# Altium schematic sheet isoSPI_Interface.SchDoc(isoSPI_Interface)
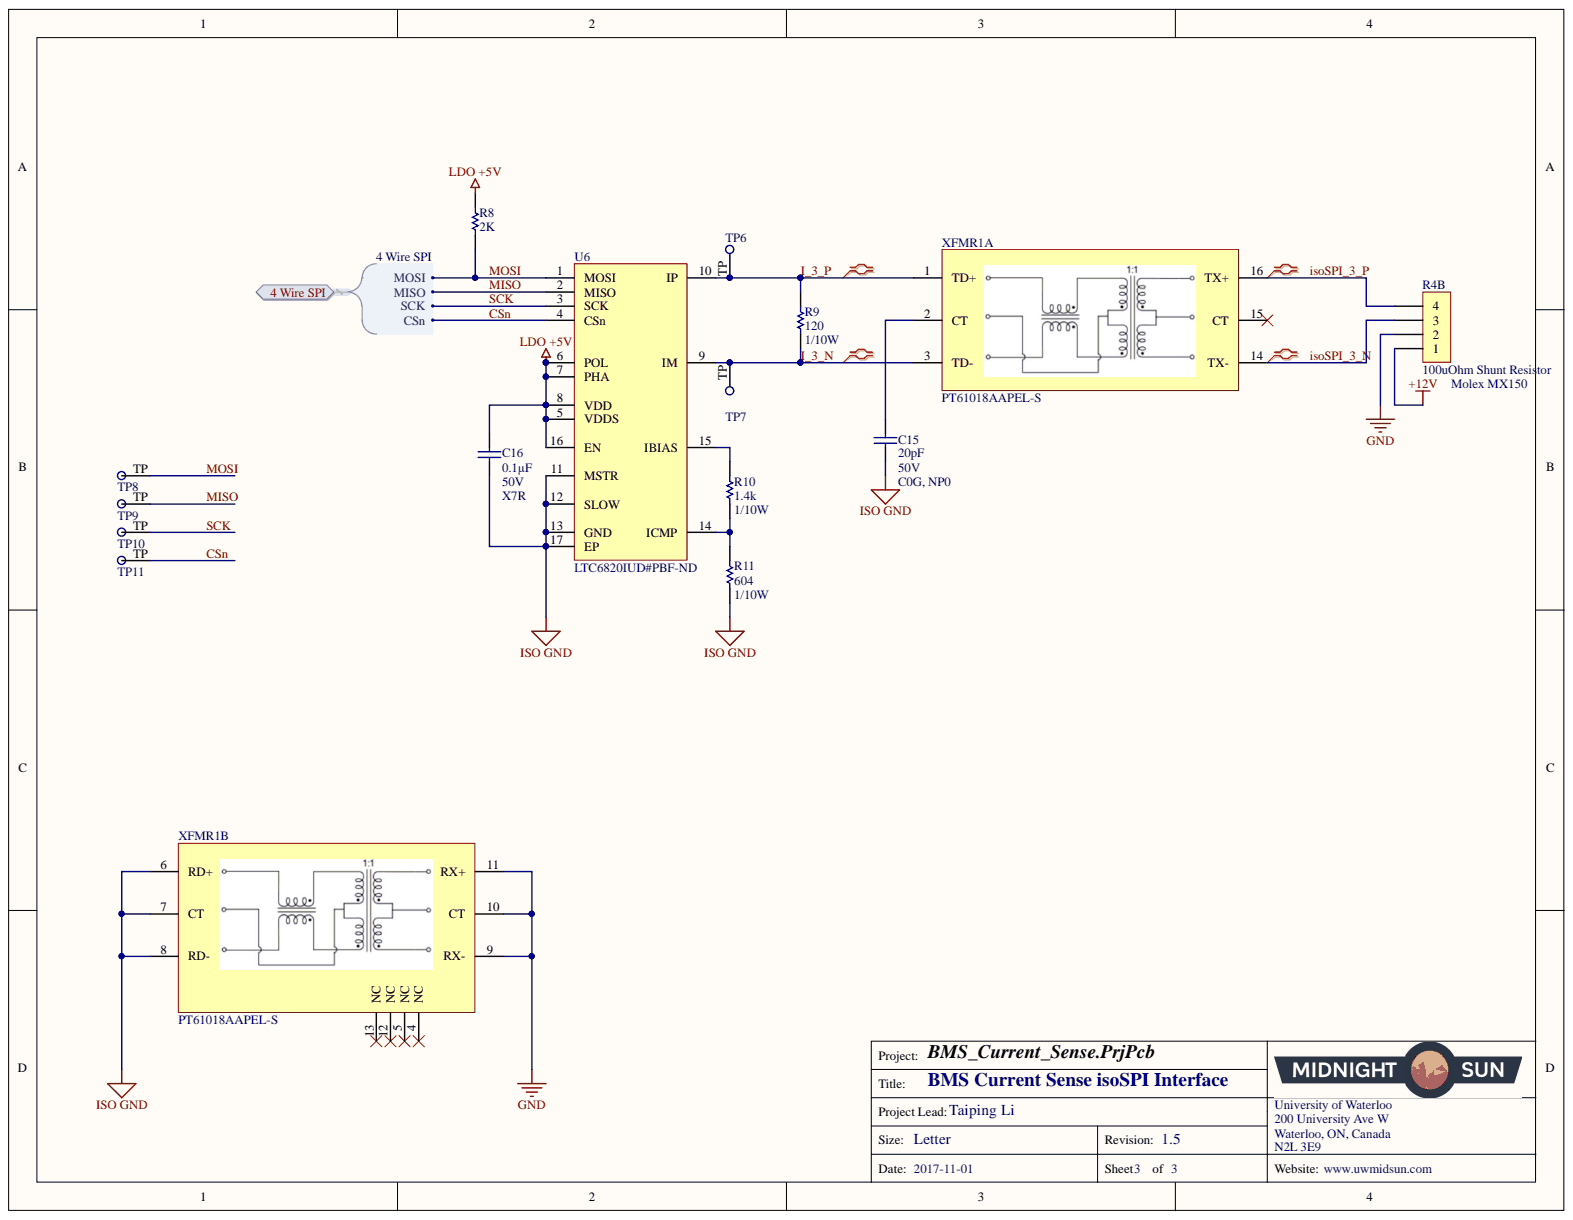
[diagram p0: isoSPI_Interface.SchDoc]

## Parts
C15  Voltage: 50V  Capacitance: 20pF  [CAP, 0603]  (hidden comment: CAP CER 20PF 50V ±5% C0G/NP0 0603)
C16  Voltage: 50V  Temperature Coefficient: X7R  Capacitance: 0.1µF  [CAP, 0603]  (hidden comment: CAP CER 0.1UF 50V 10% X7R 0603)
R4  100uOhm Shunt Resistor  [RES SHUNT 100UOHM 60MM]  (part B)
R8  2K  [RES, 0603]
R9  Power: 1/10W  [RES, 0603]  (hidden comment: RES 120 OHM 1% 1/10W 0603)
R10  Resistance: 1.4k  Power: 1/10W  [RES, 0603]  (hidden comment: RES 1.4k OHM 1% 1/10W 0603)
R11  Power: 1/10W  [RES, 0603]  (hidden comment: RES 604 OHM 1% 1/10W 0603)
TP6  Test Point  [Test Point]  (value not drawn)
TP7  Test Point  [Test Point]  (value not drawn)
TP8  Test Point  [Test Point]  (value not drawn)
TP9  Test Point  [Test Point]  (value not drawn)
TP10  Test Point  [Test Point]  (value not drawn)
TP11  Test Point  [Test Point]  (value not drawn)
U6  Supplier Part Number 1: LTC6820IUD#PBF-ND  [IC COMM INTERFACE ISOSPI 16-QFN]  (hidden comment: IC ISOSPI COMM INTERFACE LTC6820IUD)
XFMR1  MFG_PN: PT61018AAPEL-S  [IC, PULSE XFMR 1CT:1CT 350UH SMD]  (hidden comment: IC PULSE XFMR 1CT:1CT 350UH SMD)  (parts A,B)

## Ports
4 Wire SPI, 4 Wire SPI.CSn, 4 Wire SPI.MISO, 4 Wire SPI.MOSI, 4 Wire SPI.SCK

## Nets
+12V: R4.1
GND: R4.2 XFMR1.9(RX-) XFMR1.10(CT) XFMR1.11(RX+)
ISO GND: C15.2 C16.2 R11.2 U6.11(MSTR) U6.12(SLOW) U6.13(GND) U6.17(EP) XFMR1.6(RD+) XFMR1.7(CT) XFMR1.8(RD-)
LDO +5V: C16.1 R8.1 U6.5(VDDS) U6.6(POL) U6.7(PHA) U6.8(VDD) U6.16(EN)
CSn: TP11.TP U6.4(CSn) ; port 4 Wire SPI
I_3_N: R9.2 TP7.TP U6.9(IM) XFMR1.3(TD-)  [also labelled I_N]
I_3_P: R9.1 TP6.TP U6.10(IP) XFMR1.1(TD+)  [also labelled I_P]
isoSPI_3_N: R4.3 XFMR1.14(TX-)  [also labelled isoSPI_N]
isoSPI_3_P: R4.4 XFMR1.16(TX+)  [also labelled isoSPI_P]
MISO: TP9.TP U6.2(MISO) ; port 4 Wire SPI
MOSI: R8.2 TP8.TP U6.1(MOSI) ; port 4 Wire SPI
SCK: TP10.TP U6.3(SCK) ; port 4 Wire SPI
NetC15_1: C15.1 XFMR1.2(CT)
NetR10_1: R10.1 U6.15(IBIAS)
NetR10_2: R10.2 R11.1 U6.14(ICMP)

Not connected: XFMR1 4(NC) 5(NC) 12(NC) 13(NC) 15(CT)

## Library data of the parts
C15 = CAP CER 20PF 50V ±5% C0G/NP0 0603: 20pF ±5% 50V Ceramic Capacitor C0G, NP0 0603 (1608 Metric); Manufacturer Part Number 1: GRM1885C1H200JA01D; Manufacturer 1: Murata Electronics North America; Tolerance: ±5%; Thickness (Max): 0.035" (0.90mm); Temperature Coefficient: C0G, NP0; Supplier Part Number 1: 490-1410-1-ND; Supplier 1: Digi-Key; Size / Dimension: 0.063" L x 0.031" W (1.60mm x 0.80mm); Ratings: -; Part Status: Active; Packaging: Cut Tape (CT); Package / Case: 0603 (1608 Metric); Operating Temperature: -55°C ~ 125°C; Mounting Type: Surface Mount, MLCC; Lead Style: -; Lead Spacing: -; Height - Seated (Max): -; ComponentLink1URL: http://www.murata.com/~/media/webrenewal/support/library/catalog/products/capacitor/mlcc/c02e.ashx; ComponentLink1Description: http://www.murata.com/~/media/webrenewal/support/library/catalog/products/capacitor/mlcc/c02e.ashx; Component Kind: Standard; Library Name: Schematic Diagrams.SchLib
C16 = CAP CER 0.1UF 50V 10% X7R 0603: 0.10µF ±10% 50V Ceramic Capacitor X7R 0603 (1608 Metric); Manufacturer Part Number 1: 06035C104KAT2A; Manufacturer 1: AVX Corporation; Tolerance: ±10%; Thickness (Max): 0.035" (0.90mm); Supplier Part Number 1: 478-5052-1-ND; Supplier 1: Digi-Key; Size / Dimension: 0.063" L x 0.032" W (1.60mm x 0.81mm); Ratings: -; Part Status: Active; Packaging: Cut Tape (CT); Package / Case: 0603 (1608 Metric); Operating Temperature: -55°C ~ 125°C; Mounting Type: Surface Mount, MLCC; Lead Style: -; Lead Spacing: -; Height - Seated (Max): -; ComponentLink1URL: http://datasheets.avx.com/X7RDielectric.pdf; ComponentLink1Description: http://datasheets.avx.com/X7RDielectric.pdf; Component Kind: Standard; Library Name: Schematic Diagrams.SchLib
R4 = RES SHUNT 100UOHM 5% 36W 60MM: 100 µOhms ±5% 36W Shunt, Battery Specialized Resistor Metal Element ±175ppm/°C Shunt Fixture, 60mm Pitch; Manufacturer Part Number 1: WSBM8518L1000JK; Manufacturer 1: Vishay Dale; Type: Shunt, Battery; Tolerance: ±5%; Temperature Coefficient: ±175ppm/°C; Supplier Part Number 1: 541-1906-ND; Supplier 1: Digi-Key; Stock 1: 0; RoHS: RoHS Compliant; Pricing 1: 1=16.24, 10=14.764, 25=13.2876, 50=11.442, 100=10.5192, 250=9.9656, 500=9.5965, 1000=9.15359 (CAD); Power (Watts): 36W; Part Status: Active; Packaging: Bulk; Operating Temperature: -65°C ~ 170°C; Mounting Type: Shunt Fixture, 60mm Pitch; Description 1: RES SHUNT 100 UOHM 5% 36W; Composition: Metal Element; ComponentLink1URL: http://www.vishay.com/docs/31094/wsbm8518.pdf; ComponentLink1Description: http://www.vishay.com/docs/31094/wsbm8518.pdf; Component Kind: Standard; Category: Resistors; Applications: -; Library Name: Schematic Diagrams.SchLib
R8 = RES 2K OHM 1% 1/10W 0603: 2k Ohm ±1% 0.1W, 1/10W Chip Resistor 0603 (1608 Metric) Moisture Resistant Thick Film; Manufacturer Part Number: RC0603FR-072KL; Manufacturer: Yageo; Temperature Coefficient: ±100ppm/°C; Tolerance: ±1%; Supplier Part Number 1: 311-2.00KHRCT-ND; Supplier Device Package: 0603; Supplier 1: Digi-Key; Stock 1: 1750701; Size / Dimension: 0.063" L x 0.031" W (1.60mm x 0.80mm); RoHS: RoHS Compliant; Resistance (Ohms): 2k; Pricing 1: 1=0.15, 10=0.023, 100=0.0093, 1000=0.00415, 2500=0.0036 (CAD); Power (Watts): 0.1W, 1/10W; Part Status: Active; Packaging: Cut Tape (CT); Package / Case: 0603 (1608 Metric); Operating Temperature: -55°C ~ 155°C; Height - Seated (Max): 0.022" (0.55mm); Features: Moisture Resistant; Failure Rate: -; Composition: Thick Film; ComponentLink1URL: http://www.yageo.com/documents/recent/PYu-RC_Group_51_RoHS_L_7.pdf; ComponentLink1Description: http://www.yageo.com/documents/recent/PYu-RC_Group_51_RoHS_L_7.pdf; Component Kind: Standard; Category: Resistors; Library Name: Schematic Diagrams.SchLib
R9 = RES 120 OHM 1% 1/10W 0603: RES SMD 120 OHM 1% 1/10W 0603; Manufacturer Part Number 1: RC0603FR-07120RL; Manufacturer 1: Yageo; Temperature Coefficient: ±100ppm/°C; Resistance: 120; Tolerance: ±1%; Supplier Part Number 1: 311-120HRCT-ND; Supplier Device Package: 0603; Supplier 1: Digi-Key; Size / Dimension: 0.063" L x 0.031" W (1.60mm x 0.80mm); Part Status: Active; Packaging: Cut Tape (CT); Package / Case: 0603 (1608 Metric); Operating Temperature: -55°C ~ 155°C; Height - Seated (Max): 0.022" (0.55mm); Failure Rate: -; Composition: Thick Film; ComponentLink1URL: http://www.yageo.com/documents/recent/PYu-RC_Group_51_RoHS_L_7.pdf; ComponentLink1Description: http://www.yageo.com/documents/recent/PYu-RC_Group_51_RoHS_L_7.pdf; Component Kind: Standard; Library Name: Schematic Diagrams.SchLib
R10 = RES 1.4k OHM 1% 1/10W 0603: RES SMD 1.4K OHM 1% 1/10W 0603; Manufacturer Part Number 1: RC0603FR-071K4L; Manufacturer 1: Yageo; Temperature Coefficient: ±100ppm/°C; Tolerance: ±1%; Supplier Part Number 1: 311-1.40KHRCT-ND; Supplier Device Package: 0603; Supplier 1: Digi-Key; Size / Dimension: 0.063" L x 0.031" W (1.60mm x 0.80mm); Part Status: Active; Packaging: Cut Tape (CT); Package / Case: 0603 (1608 Metric); Operating Temperature: -55°C ~ 155°C; Height - Seated (Max): 0.022" (0.55mm); Failure Rate: -; Composition: Thick Film; ComponentLink1URL: http://www.yageo.com/documents/recent/PYu-RC_Group_51_RoHS_L_7.pdf; ComponentLink1Description: http://www.yageo.com/documents/recent/PYu-RC_Group_51_RoHS_L_7.pdf; Component Kind: Standard; Library Name: Schematic Diagrams.SchLib
R11 = RES 604 OHM 1% 1/10W 0603: RES SMD 604 OHM 1% 1/10W 0603; Manufacturer Part Number 1: RC0603FR-07604RL; Manufacturer 1: Yageo; Temperature Coefficient: ±100ppm/°C; Resistance: 604; Tolerance: ±1%; Supplier Part Number 1: 311-604HRCT-ND; Supplier Device Package: 0603; Supplier 1: Digi-Key; Size / Dimension: 0.063" L x 0.031" W (1.60mm x 0.80mm); Part Status: Active; Packaging: Cut Tape (CT); Package / Case: 0603 (1608 Metric); Operating Temperature: -55°C ~ 155°C; Height - Seated (Max): 0.022" (0.55mm); Failure Rate: -; Composition: Thick Film; ComponentLink1URL: http://www.yageo.com/documents/recent/PYu-RC_Group_51_RoHS_L_7.pdf; ComponentLink1Description: http://www.yageo.com/documents/recent/PYu-RC_Group_51_RoHS_L_7.pdf; Component Kind: Standard; Library Name: Schematic Diagrams.SchLib
U6 = IC ISOSPI COMM INTERFACE LTC6820IUD: IC COMM INTERFACE ISOSPI 16-QFN; Manufacturer Part Number: LTC6820IUD#PBF; Manufacturer: Linear Technology; Voltage - Supply: 2.7 V ~ 5.5 V; Interface: SPI; Supplier Device Package: 16-QFN (3x3); Supplier 1: Digi-Key; Stock 1: 827; RoHS: RoHS Compliant; Pricing 1: 1=7.35, 25=4.8816, 100=4.0066 (CAD); Part Status: Active; Packaging: Tube; Package / Case: 16-WFQFN Exposed Pad; Mounting Type: Surface Mount; ComponentLink1URL: http://www.linear.com/docs/42317; ComponentLink1Description: http://www.linear.com/docs/42317; Component Kind: Standard; Category: Integrated Circuits (ICs); Applications: Isolated Communications Interface; Library Name: Schematic Diagrams.SchLib
XFMR1 = IC PULSE XFMR 1CT:1CT 350UH SMD: PULSE XFMR 1CT:1CT 350UH SMD; Manufacturer: Bourns Inc.; Isolation Voltage: 1.5kVrms; Supplier Part Number 1: PT61018AAPEL-SCT-ND; Supplier_PN: PT61018AAPEL-SCT-ND; Supplier 1: Digi-Key; Supplier: Digikey; Inductance: 350uH; Component Kind: Standard; Library Name: Schematic Diagrams.SchLib
TP6-TP11 = Test Point: Component Kind: Standard; Library Name: Schematic Diagrams.SchLib
